# Altium schematic sheet DebugPcb.SchDoc
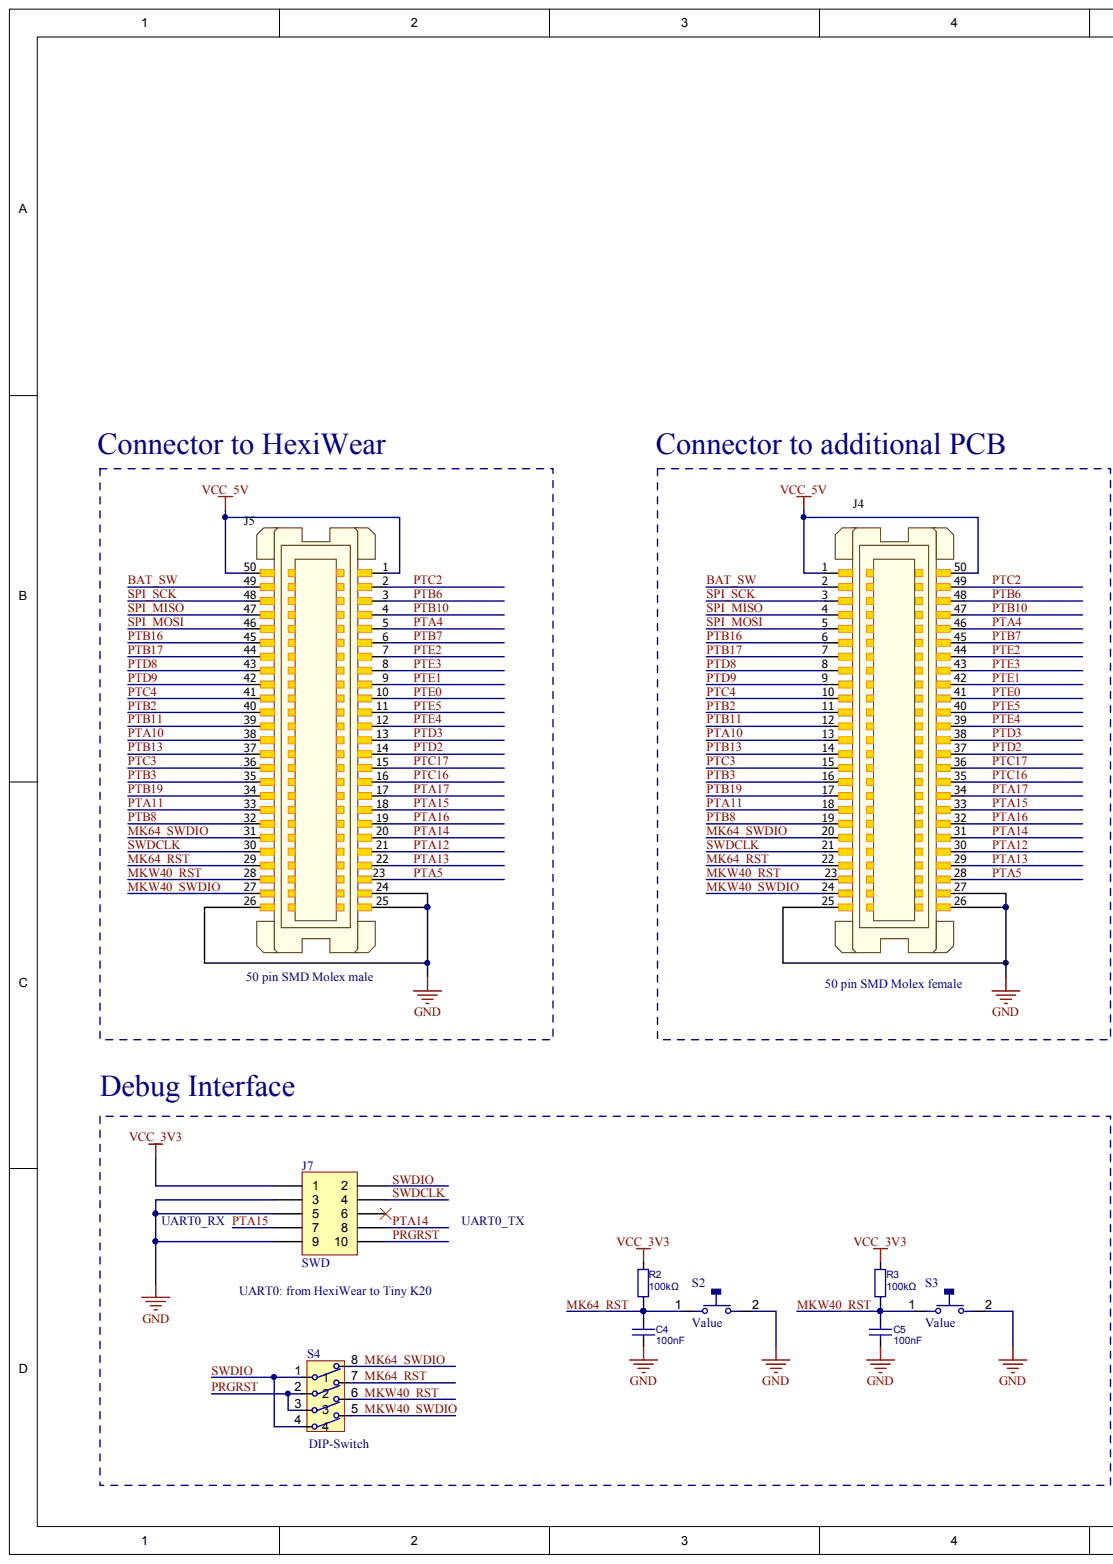
[diagram p0: DebugPcb.SchDoc, left]
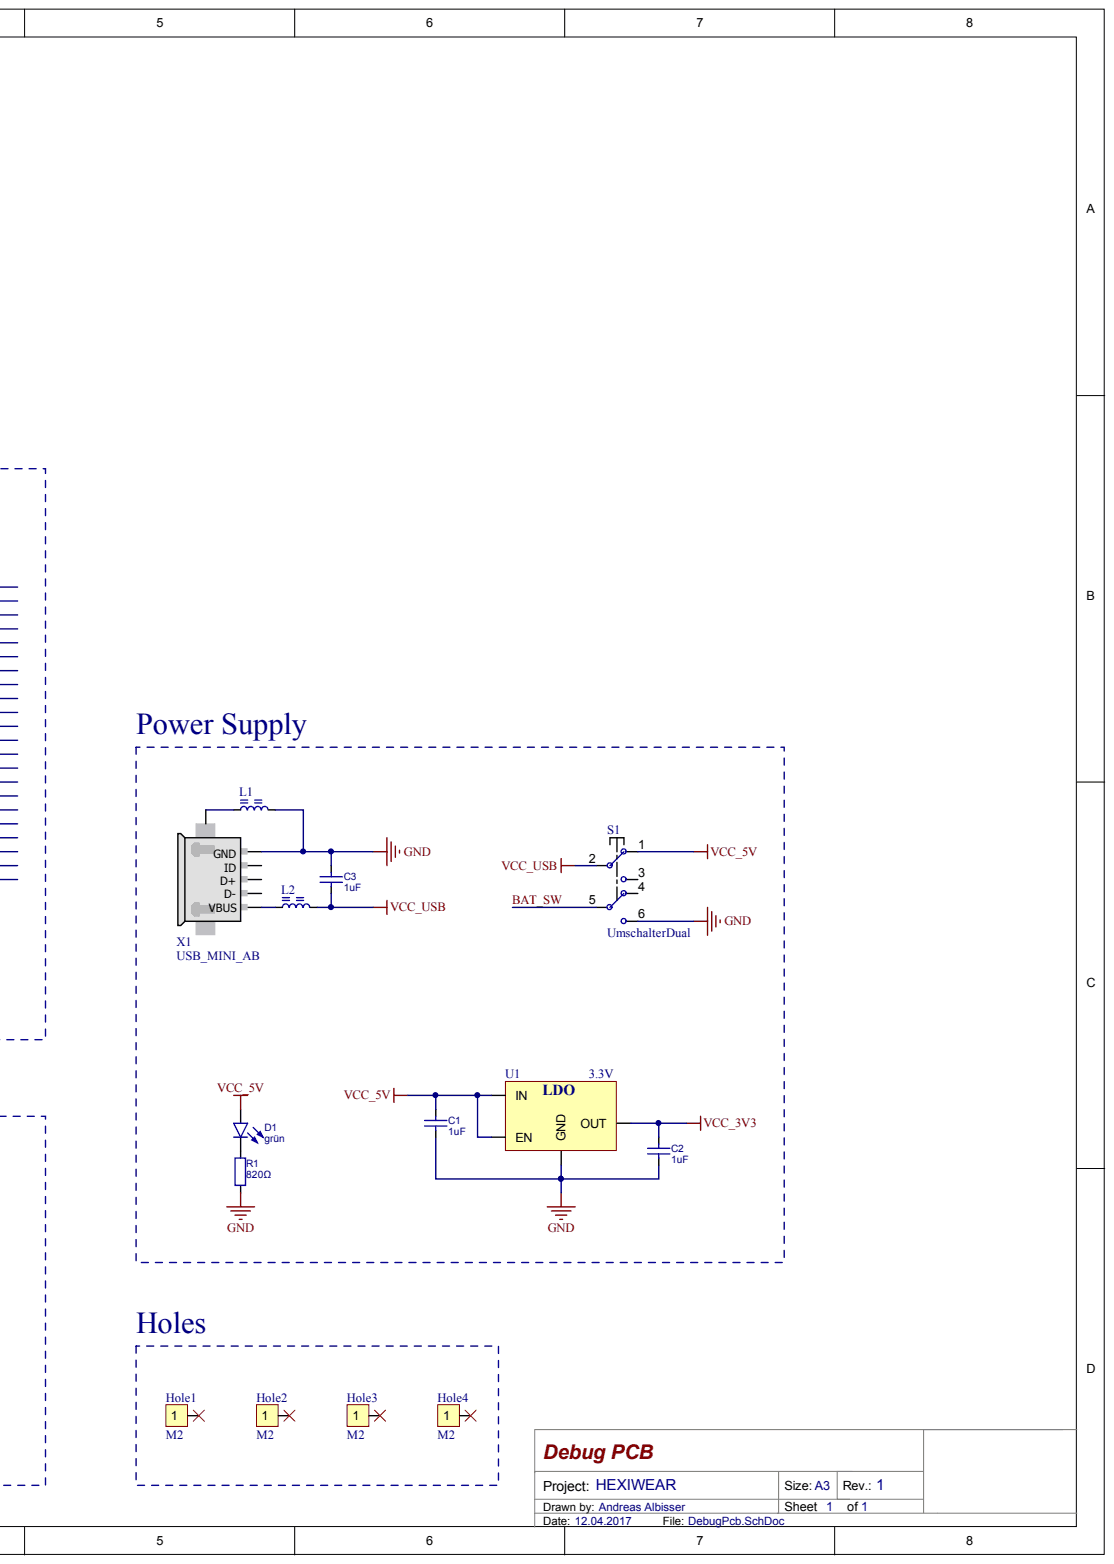
[diagram p1: DebugPcb.SchDoc, right]

## Parts
C1  1uF  [0603]
C2  1uF  [0603]
C3  1uF  [0603]
C4  100nF  [0603]
C5  100nF  [0603]
D1  grün  [0805D]
Hole1  M2  [Hole_2.2mm_pad]
Hole2  M2  [Hole_2.2mm_pad]
Hole3  M2  [Hole_2.2mm_pad]
Hole4  M2  [Hole_2.2mm_pad]
J4  50 pin SMD Molex female  [CON_SMD_50P_HexiWear_female]
J5  50 pin SMD Molex male  [CON_SMD_50P_HexiWear_male]
J7  SWD  [CON_SMD_2x5P_RM1.27]
L1  ?  [0603]  (value not drawn)
L2  ?  [0603]  (value not drawn)
R1  820Ω  [0603]
R2  100kΩ  [0603]
R3  100kΩ  [0603]
S1  UmschalterDual  [SW_MCLSS22]
S2  Value  [TA_SW_B3U-1000P]
S3  Value  [TA_SW_B3U-1000P]
S4  DIP-Switch  [SW_DIP_1.27_4P]
U1  3.3V  [SOT-23-5]
X1  USB_MINI_AB  [CON_SMD_USB_MICRO]

## Nets
GND: C1.1 C2.1 C3.2 C4.1 C5.1 J4.25 J4.26 J4.27 J5.24 J5.25 J5.26 J7.3 J7.5 J7.9 L1.2 R1.1 S1.6 S2.2 S3.2 U1.2(GND) X1.5(GND)
VCC_3V3: C2.2 J7.1 R2.2 R3.2 U1.5(OUT)
VCC_5V: C1.2 D1.1 J4.1 J4.50 J5.1 J5.50 S1.1 U1.1(IN) U1.3(EN)
VCC_USB: C3.1 L2.2 S1.2
BAT_SW: J4.2 J5.49 S1.5
MK64_RST: C4.2 J4.22 J5.29 R2.1 S2.1 S4.7
MK64_SWDIO: J4.20 J5.31 S4.8
MKW40_RST: C5.2 J4.23 J5.28 R3.1 S3.1 S4.6
MKW40_SWDIO: J4.24 J5.27 S4.5
PRGRST: J7.10 S4.2 S4.3
PTA4: J4.46 J5.5
PTA5: J4.28 J5.23
PTA10: J4.13 J5.38
PTA11: J4.18 J5.33
PTA12: J4.30 J5.21
PTA13: J4.29 J5.22
PTA14: J4.31 J5.20 J7.8
PTA15: J4.33 J5.18 J7.7
PTA16: J4.32 J5.19
PTA17: J4.34 J5.17
PTB2: J4.11 J5.40
PTB3: J4.16 J5.35
PTB6: J4.48 J5.3
PTB7: J4.45 J5.6
PTB8: J4.19 J5.32
PTB10: J4.47 J5.4
PTB11: J4.12 J5.39
PTB13: J4.14 J5.37
PTB16: J4.6 J5.45
PTB17: J4.7 J5.44
PTB19: J4.17 J5.34
PTC2: J4.49 J5.2
PTC3: J4.15 J5.36
PTC4: J4.10 J5.41
PTC16: J4.35 J5.16
PTC17: J4.36 J5.15
PTD2: J4.37 J5.14
PTD3: J4.38 J5.13
PTD8: J4.8 J5.43
PTD9: J4.9 J5.42
PTE0: J4.41 J5.10
PTE1: J4.42 J5.9
PTE2: J4.44 J5.7
PTE3: J4.43 J5.8
PTE4: J4.39 J5.12
PTE5: J4.40 J5.11
SPI_MISO: J4.4 J5.47
SPI_MOSI: J4.5 J5.46
SPI_SCK: J4.3 J5.48
SWDCLK: J4.21 J5.30 J7.4
SWDIO: J7.2 S4.1 S4.4
NetD1_2: D1.2 R1.2
NetL1_1: L1.1 X1.SHIELD
NetL2_1: L2.1 X1.1(VBUS)

Not connected: Hole1 1; Hole2 1; Hole3 1; Hole4 1; J7 6; S1 3 4; X1 2(D-) 3(D+) 4(ID)

## Library data of the parts
C1-C5 = 4: Keramikkondensator 0603; Changed by: taalbiss; Database Table Name: Capacitors - Ceramic; Number of pads: 2; Category: Capacitors - Ceramic; Changed at: 21.07.2015 17:44:32; Component Kind: Standard; ComponentLink1Description: Mouser 0603 Ceramic Capacitors; ComponentLink1URL: http://www.mouser.ch/Passive-Components/Capacitors/Ceramic-Capacitors/MLCCs-Multilayer-Ceramic-Capacitors/Multilayer-Ceramic-Capacitors-MLCC-SMD-SMT/_/N-bkrdv?P=1yzmou6; Distributor: Mouser; Distributor Order Number: 0; Part ID: 4; Price: 0; SMD: True; Library Name: CCE_Database_Libs.SVNDbLib
D1 = 110: LED SMD 0805; Changed by: taalbiss; Database Table Name: Optical; Number of pads: 2; Category: Optical; Changed at: 28.08.2015 11:10:10; Component Kind: Standard; ComponentLink1Description: Mouser 0805 LED's; ComponentLink1URL: http://www.mouser.ch/Optoelectronics/LED-Lighting/LED-Emitters/Standard-LEDs-SMD/_/N-b1bb1?P=1yzvlvbZ1yzvlks; Distributor: Mouser; Distributor Order Number: 0; Part ID: 110; Price: 0; SMD: True; Library Name: CCE_Database_Libs.SVNDbLib
Hole1-Hole4 = Hole: Component Kind: Standard; Library Name: Sch_Lib.SchLib
J4 J5 = 50 pin SMD Molex: DF12(3.0)-50DS-0.5V(86); Component Kind: Standard; SMD: Yes; Library Name: WunderBar_v102.SCHLIB
J7 = Header 5X2: Header, 5-Pin, Dual row 0.5" RM; Component Kind: Standard; Distributor: Farnell; Distributor Nr.: 1577743; Library Name: Miscellaneous Connectors.IntLib; Package: RM 0.5"; Value: SWD
L1 L2 = 45: Ferrit 0603; Changed by: taalbiss; Database Table Name: Ferrites; Number of pads: 2; Category: Ferrites; Changed at: 03.08.2015 14:45:01; Component Kind: Standard; ComponentLink1Description: Mouser 0603 Ferrites; ComponentLink1URL: http://www.mouser.ch/Passive-Components/EMI-RFI-Components/EMI-Filter-Beads-Chips-Arrays/_/N-bw7pa?P=1yzvlkx; Distributor: Mouser; Distributor Order Number: 0; Part ID: 45; Price: 0; SMD: True; Library Name: CCE_Database_Libs.SVNDbLib
R1-R3 = 2: Widerstand 0603; Changed by: taalbiss; Database Table Name: Resistors; Category: Resistors; Changed at: 21.07.2015 17:44:48; Component Kind: Standard; ComponentLink1Description: Mouser 0603 Resistors; ComponentLink1URL: http://www.mouser.ch/Passive-Components/Resistors/SMD-Resistors-Chip-Resistors/_/N-7h7yu?P=1yzmou6; Distributor: Mouser; Distributor Order Number: 0; Number of pads: 2; Part ID: 2; Price: 0; SMD: True; Library Name: CCE_Database_Libs.SVNDbLib
S2 S3 = TA_SW_2x1: Component Kind: Standard; Library Name: Sch_Lib.SchLib
S4 = SW_4_in_1: Component Kind: Standard; Library Name: Sch_Lib.SchLib
U1 = 127: LDO Fix mit Enable SOT-23-5; Changed by: taalbiss; Database Table Name: IC - Power; Number of pads: 5; Category: IC - Power; Changed at: 14.09.2015 12:42:09; Component Kind: Standard; ComponentLink1Description: Mouser SOT-23-5 LDO's; ComponentLink1URL: http://www.mouser.ch/Semiconductors/Power-Management-ICs/LDO-Voltage-Regulators/_/N-5cgac?P=1yx5k7vZ1z0z33q; Distributor: Mouser; Distributor Order Number: 511-LDK320AM33R; Part ID: 127; Price: 0; SMD: True; Library Name: CCE_Database_Libs.SVNDbLib
S1 = UmschalterDual: Component Kind: Standard; Library Name: Hex.SchLib
X1 = USB_MINI_AB: Component Kind: Standard; Library Name: Hex.SchLib
